# Altium schematic sheet B14_B16.SchDoc(B14_B16)
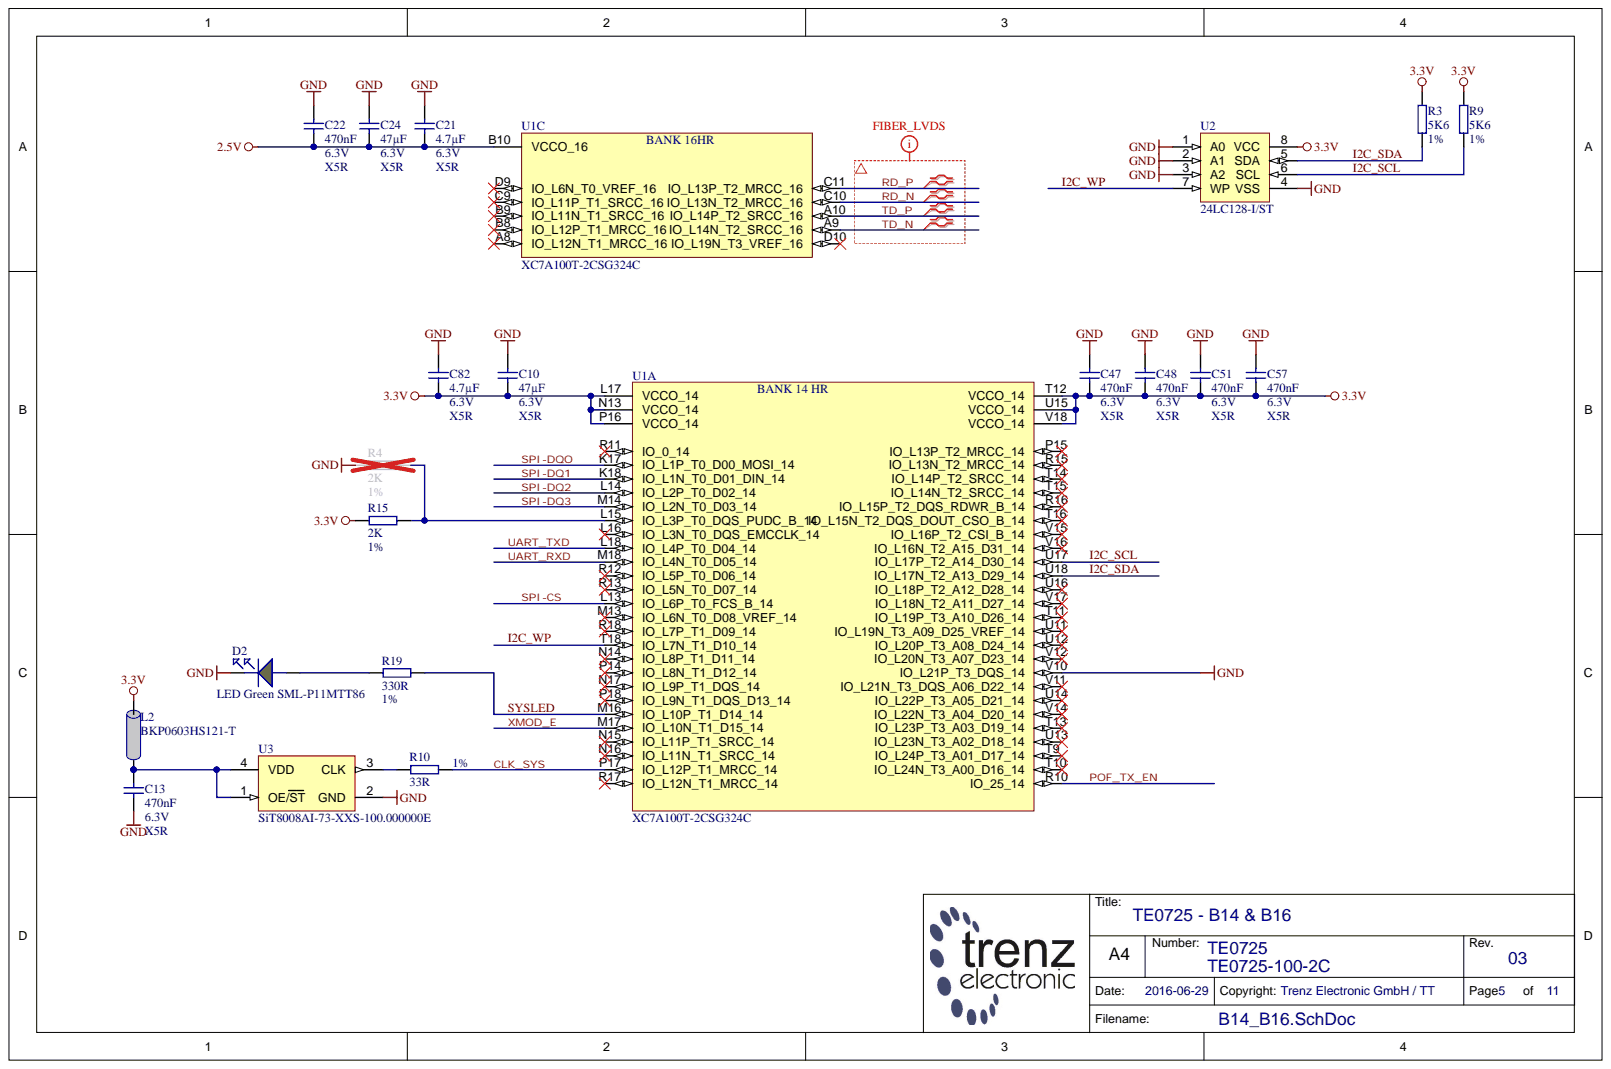
[diagram p0: B14_B16.SchDoc]

## Parts
C10  47µF  Technology: X5R  Voltage: 6.3V  [CAPC2012X135N]
C13  470nF  Technology: X5R  Voltage: 6.3V  [CAPC0603X33N]
C21  4.7µF  Technology: X5R  Voltage: 6.3V  [CAPC1005X60N]
C22  470nF  Technology: X5R  Voltage: 6.3V  [CAPC0603X33N]
C24  47µF  Technology: X5R  Voltage: 6.3V  [CAPC2012X135N]
C47  470nF  Technology: X5R  Voltage: 6.3V  [CAPC0603X33N]
C48  470nF  Technology: X5R  Voltage: 6.3V  [CAPC0603X33N]
C51  470nF  Technology: X5R  Voltage: 6.3V  [CAPC0603X33N]
C57  470nF  Technology: X5R  Voltage: 6.3V  [CAPC0603X33N]
C82  4.7µF  Technology: X5R  Voltage: 6.3V  [CAPC1005X60N]
D2  LED Green SML-P11MTT86  Database Table Name: LED  H-ART: SML-P11MTT86  [LEDC1006X25N_GREEN]
L2  BKP0603HS121-T  [INDC0603X33N]
R3  5K6  Tolerance: 1%  [RESC1005X40N]
R4  2K  [RESC1005X40N]
R9  5K6  Tolerance: 1%  [RESC1005X40N]
R10  33R  Tolerance: 1%  [RESC1005X40N]
R15  2K  Tolerance: 1%  [RESC1005X40N]
R19  330R  Tolerance: 1%  [RESC1005X40N]
U1  XC7A100T-2CSG324C  [BGA324C80P18X18_1500X1500X150N]  (parts A,C)
U2  24LC128-I/ST  [SOP65P640X120-8N-MC]
U3  SiT8008AI-73-XXS-100.000000E  Database Table Name: CLK  [2016]

## Nets
2.5V: C21.2 C22.2 C24.2 U1.B10(VCCO_16)
3.3V: C10.2 C47.2 C48.2 C51.2 C57.2 C82.2 L2.2 R3.2 R9.2 R15.1 U1.L17(VCCO_14) U1.N13(VCCO_14) U1.P16(VCCO_14) U1.T12(VCCO_14) U1.U15(VCCO_14) U1.V18(VCCO_14) U2.8(VCC)
GND: C10.1 C13.1 C21.1 C22.1 C24.1 C47.1 C48.1 C51.1 C57.1 C82.1 D2.K R4.1 U1.V10(IO_L21P_T3_DQS_14) U2.1(A0) U2.2(A1) U2.3(A2) U2.4(VSS) U3.2(GND)
CLK_SYS: R10.2 U1.P17(IO_L12P_T1_MRCC_14)
I2C_SCL: R9.1 U1.U17(IO_L17P_T2_A14_D30_14) U2.6(SCL)
I2C_SDA: R3.1 U1.U18(IO_L17N_T2_A13_D29_14) U2.5(SDA)
I2C_WP: U1.T18(IO_L7N_T1_D10_14) U2.7(WP)
POF_TX_EN: U1.R10(IO_25_14)
RD_N: U1.C10(IO_L13N_T2_MRCC_16)
RD_P: U1.C11(IO_L13P_T2_MRCC_16)
SPI-CS: U1.L13(IO_L6P_T0_FCS_B_14)
SPI-DQ1: U1.K18(IO_L1N_T0_D01_DIN_14)
SPI-DQ2: U1.L14(IO_L2P_T0_D02_14)
SPI-DQ3: U1.M14(IO_L2N_T0_D03_14)
SPI-DQO: U1.K17(IO_L1P_T0_D00_MOSI_14)
SYSLED: R19.1 U1.M16(IO_L10P_T1_D14_14)
TD_N: U1.A9(IO_L14N_T2_SRCC_16)
TD_P: U1.A10(IO_L14P_T2_SRCC_16)
UART_RXD: U1.M18(IO_L4N_T0_D05_14)
UART_TXD: U1.L18(IO_L4P_T0_D04_14)
XMOD_E: U1.M17(IO_L10N_T1_D15_14)
NetC13_2: C13.2 L2.1 U3.1(OE/ST) U3.4(VDD)
NetD2_A: D2.A R19.2
NetR4_2: R4.2 R15.2 U1.L15(IO_L3P_T0_DQS_PUDC_B_14)
NetR10_1: R10.1 U3.3(CLK)
N00104:

Not connected: U1 A8(IO_L12N_T1_MRCC_16) B8(IO_L12P_T1_MRCC_16) B9(IO_L11N_T1_SRCC_16) C9(IO_L11P_T1_SRCC_16) D9(IO_L6N_T0_VREF_16) D10(IO_L19N_T3_VREF_16) L16(IO_L3N_T0_DQS_EMCCLK_14) M13(IO_L6N_T0_D08_VREF_14) N14(IO_L8P_T1_D11_14) N15(IO_L11P_T1_SRCC_14) N16(IO_L11N_T1_SRCC_14) N17(IO_L9P_T1_DQS_14) P14(IO_L8N_T1_D12_14) P15(IO_L13P_T2_MRCC_14) P18(IO_L9N_T1_DQS_D13_14) R11(IO_0_14) R12(IO_L5P_T0_D06_14) R13(IO_L5N_T0_D07_14) R15(IO_L13N_T2_MRCC_14) R16(IO_L15P_T2_DQS_RDWR_B_14) R17(IO_L12N_T1_MRCC_14) R18(IO_L7P_T1_D09_14) T9(IO_L24P_T3_A01_D17_14) T10(IO_L24N_T3_A00_D16_14) T11(IO_L19P_T3_A10_D26_14) T13(IO_L23P_T3_A03_D19_14) T14(IO_L14P_T2_SRCC_14) T15(IO_L14N_T2_SRCC_14) T16(IO_L15N_T2_DQS_DOUT_CSO_B_14) U11(IO_L19N_T3_A09_D25_VREF_14) U12(IO_L20P_T3_A08_D24_14) U13(IO_L23N_T3_A02_D18_14) U14(IO_L22P_T3_A05_D21_14) U16(IO_L18P_T2_A12_D28_14) V11(IO_L21N_T3_DQS_A06_D22_14) V12(IO_L20N_T3_A07_D23_14) V14(IO_L22N_T3_A04_D20_14) V15(IO_L16P_T2_CSI_B_14) V16(IO_L16N_T2_A15_D31_14) V17(IO_L18N_T2_A11_D27_14)

## Library data of the parts
C10 C24 = 24718: KON 47µF 6,3V  X5R SMD 20%; Database Table Name: C_2012_0805; Mixed: SMT; Preferred: Yes; Value: 47u; AN: 24718; Case: 2012 (0805); Component Kind: Standard; Library Name: TE.DbLib; Tolerance: 20%
C13 C22 C47 C48 C51 C57 = 24921: KON 470nF X5R 6.3V SMD 20%; Database Table Name: C_0603_0201; Mixed: SMT; Preferred: Yes; Value: 470n; AN: 24921; Case: 0603 (0201); Component Kind: Standard; Library Name: TE.DbLib; Tolerance: 20%
C21 C82 = 24719: KON 4.7µF 6.3V X5R SMD 20%; Database Table Name: C_1005_0402; Mixed: SMT; Preferred: Yes; Value: 4.7u; AN: 24719; Case: 1005 (0402); Component Kind: Standard; Library Name: TE.DbLib; Tolerance: 20%
D2 = 24211: LED Grün SML-P11MTT86 SMD; Mixed: SMT; Preferred: Yes; AN: 24211; Case: 1005 (0402); Component Kind: Standard; Library Name: TE.DbLib; HelpURL: B:\AD\adlib\datasheet\SML-P1
L2 = 25393: Ferritdurchführung SMD 0201; Database Table Name: L_0603_0201; Mixed: SMT; Preferred: Yes; Tolerance: 25%; AN: 25393; Case: 0603 (0201); Component Kind: Standard; H-ART: BKP0603HS121-T; Library Name: TE.DbLib; Trenz-Stock: Yes; HelpURL: B:\AD\adlib\datasheet\MULTILAYER CHIP BEAD INDUCTORS BK
R3 R9 = 22760: WID SMD 5K6 1% 0,063W; Database Table Name: R_1005_0402; Mixed: SMT; Value: 5.6K; AN: 22760; Case: 1005 (0402); Component Kind: Standard; Library Name: TE.DbLib; Technology: TK100
R4 R15 = 25073: WID SMD 2K 1% 0,063W; Database Table Name: R_1005_0402; Mixed: SMT; AN: 25073; Case: 1005 (0402); Component Kind: Standard; Library Name: TE.DbLib; Technology: TK100; Value: 2K
  R4: Tolerance: 1%
R10 = 22770: WID SMD 33R 1% 0,063W; Database Table Name: R_1005_0402; Mixed: SMT; Preferred: Yes; Value: 33; AN: 22770; Case: 1005 (0402); Component Kind: Standard; Library Name: TE.DbLib; Technology: TK100
R19 = 22762: WID SMD 330R 1% 0,063W; Database Table Name: R_1005_0402; Mixed: SMT; Preferred: Yes; Value: 330; AN: 22762; Case: 1005 (0402); Component Kind: Standard; Library Name: TE.DbLib; Technology: TK100
U1 = 24908: Database Table Name: PROG_LOGIC; Mixed: SMT; Trenz-Stock: Yes; AN: 24908; Case: CSG324; Component Kind: Standard; Description: XC7A100T-2CSG324C; H-ART: XC7A100T-2CSG324C; Library Name: TE.DbLib; HelpURL: B:\AD\adlib\datasheet\XC7
U2 = 22933: Database Table Name: EEPROM; Mixed: SMT; Preferred: Yes; AN: 22933; Case: TSSOP8; Component Kind: Standard; Description: 24LC128-I/ST; H-ART: 24LC128-I/ST; Library Name: TE.DbLib; Trenz-Stock: Yes; HelpURL: B:\AD\adlib\datasheet\24LC128
U3 = 25961: SiT8008AI-73-XXS-100.000000E MEMS Oszillator 100MHz; Mixed: SMT; Preferred: Yes; Value: 100M; AN: 25961; Case: 2.0  x 1.6mm; Component Kind: Standard; H-ART: SiT8008AI-73-XXS-100.000000E; Library Name: TE.DbLib; Trenz-Stock: Yes; HelpURL: B:\AD\adlib\datasheet\SiT8008
